# Altium schematic sheet Front-End-Power-Protection.SchDoc
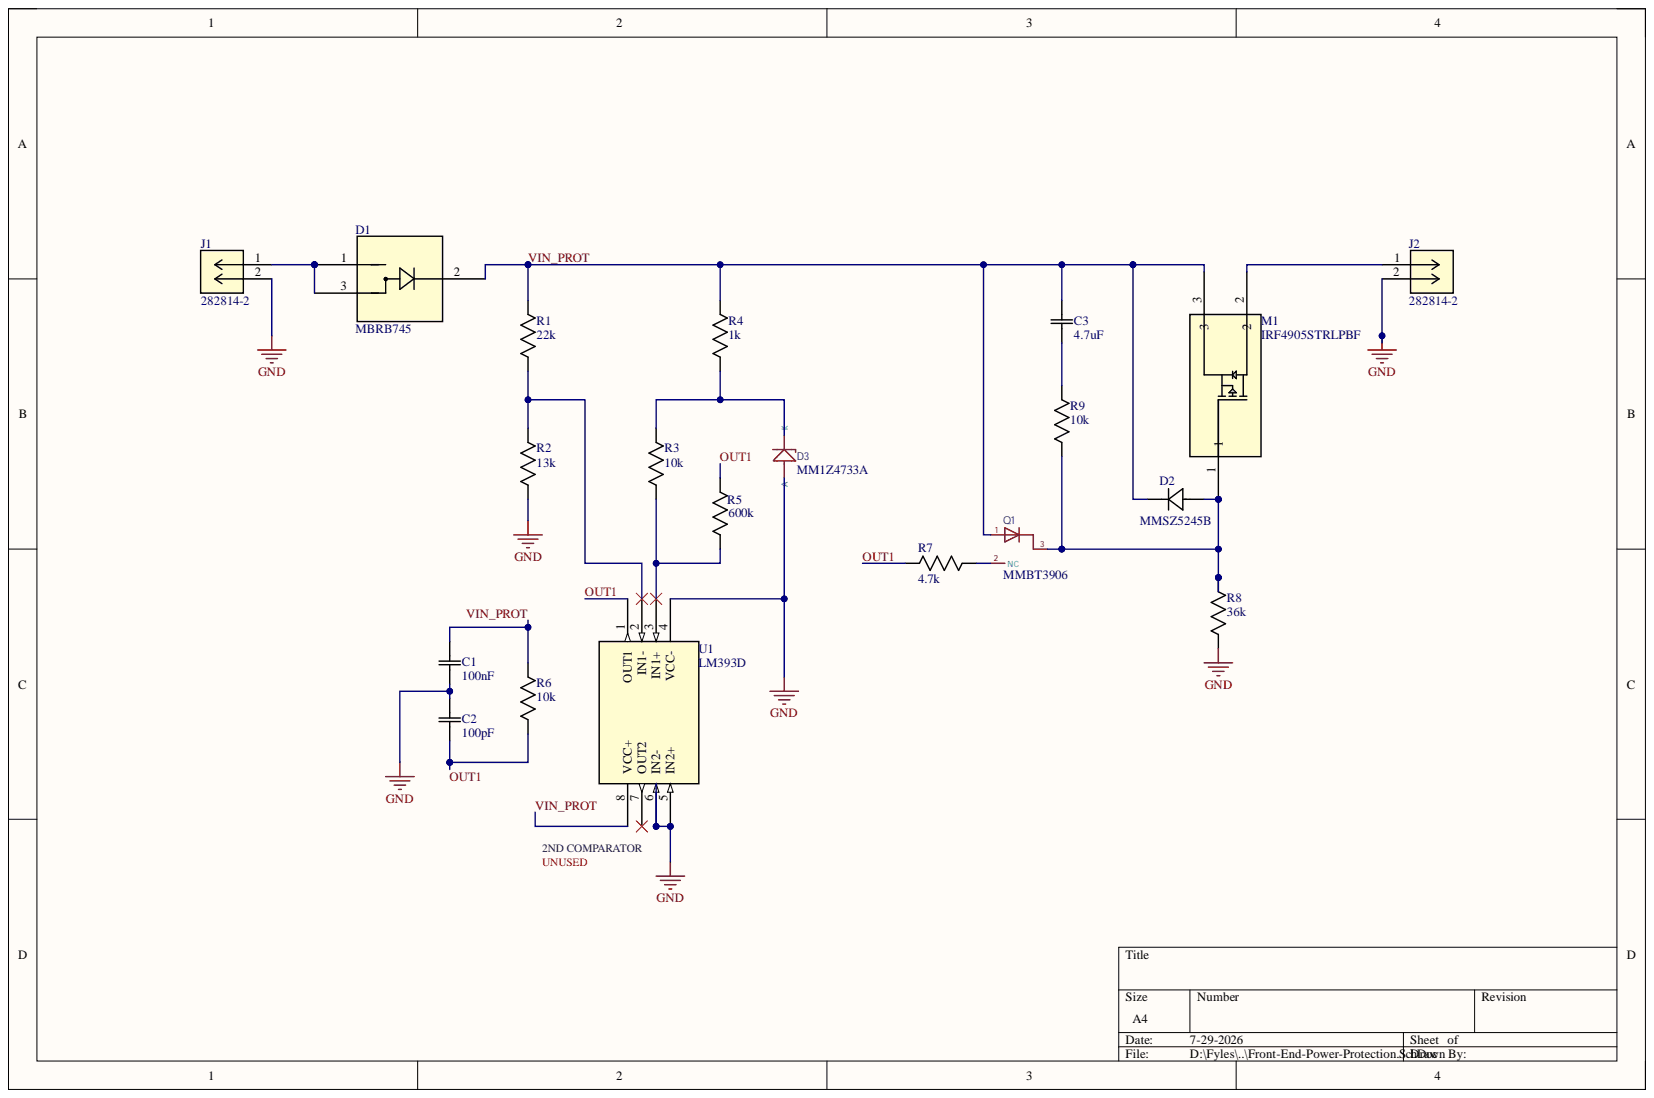
[diagram p0: Front-End-Power-Protection.SchDoc]

## Parts
C1  100nF  [CAP_X7R_0805_AVX]
C2  100pF  [CAP_X7R_0805_AVX]
C3  4.7uF  [CAP_CC1210_YAG]
D1  MBRB745  [D2PAK_VIS]
D2  MMSZ5245B  [SOD123_DIO]
D3  MM1Z4733A
J1  282814-2  [CONN2_282814-2]
J2  282814-2  [CONN2_282814-2]
M1  IRF4905STRLPBF  [TRAN_IRF4905STRLPBF_INF]
Q1  MMBT3906
R1  22k  [RC0805N_YAG]
R2  13k  [RC0805N_YAG]
R3  10k  [RC0805N_YAG]
R4  1k  [RC0805N_YAG]
R5  600k  [RC0805N_YAG]
R6  10k  [RC0805N_YAG]
R7  4.7k  [RC0805N_YAG]
R8  36k  [RC0805N_YAG]
R9  10k  [RC0805N_YAG]
U1  LM393D  [SO8_STM]

## Nets
GND: C1.1 C2.2 D3.A J1.2 J2.2 R2.2 R8.2 U1.4(VCC-) U1.5(IN2+) U1.6(IN2-)
OUT1: C2.1 R5.1 R6.2 R7.1 U1.1(OUT1)
VIN_PROT: C1.2 C3.2 D1.2 D2.1 M1.3 Q1.1 R1.1 R4.1 R6.1 U1.8(VCC+)
NetC3_1: C3.1 R9.1
NetD1_1: D1.1 D1.3 J1.1
NetD2_2: D2.2 M1.1 Q1.3 R8.1 R9.2
NetD3_K: D3.K R3.2 R4.2
NetJ2_1: J2.1 M1.2
NetQ1_2: Q1.2 R7.2
NetR1_2: R1.2 R2.1 U1.2(IN1-)
NetR3_1: R3.1 R5.2 U1.3(IN1+)

Not connected: U1 7(OUT2)

## Library data of the parts
C1 C2 = 08053C104KAT2A: Manufacturer_Name: KYOCERA AVX; Component Kind: Standard; Library Name: My_Custom_Components.IntLib; Manufacturer_Part_Number: 08053C104KAT2A; Copyright: Copyright (C) 2026 Ultra Librarian. All rights reserved.
  C1: Description: 08053C104KAT2A
C3 = CC1210KKX7R9BB475: Manufacturer_Name: Yageo; Component Kind: Standard; Description: CC1210KKX7R9BB475; Library Name: My_Custom_Components.IntLib; Manufacturer_Part_Number: CC1210KKX7R9BB475; Copyright: Copyright (C) 2026 Ultra Librarian. All rights reserved.
D1 = MBRB745: No Description Available; Manufacturer_Name: Vishay; Component Kind: Standard; Library Name: My_Custom_Components.IntLib; Manufacturer_Part_Number: MBRB745; Copyright: Copyright (C) 2026 Ultra Librarian. All rights reserved.
D2 = MMSZ5245B-7-F: Manufacturer_Name: Diodes Inc; Component Kind: Standard; Description: MMSZ5245B-7-F; Library Name: My_Custom_Components.IntLib; Manufacturer_Part_Number: MMSZ5245B-7-F; Copyright: Copyright (C) 2026 Ultra Librarian. All rights reserved.
D3 = MM1Z4733A: ki_description: CLD Current Limiting Diode; Reference: D; Value: CLD-Diode; Component Kind: Standard; Datasheet: https://diotec.com/de/produktliste/C.html; ki_keywords: CLD current limiting diode; Library Name: My_Custom_Components.IntLib
J1 J2 = 282814-2: TERMI-BLOK HEADER,ASSY90 2P.5; Manufacturer_Name: TE Connectivity; Component Kind: Standard; Datasheet: https://www.te.com/commerce/DocumentDelivery/DDEController?Action=srchrtrv&DocNm=1-1773458-1_EURO_STYLE_QRG&DocType=Data+Sheet&DocLang=English&PartCntxt=282814-2&DocFormat=pdf; Library Name: My_Custom_Components.IntLib; Manufacturer_Part_Number: 282814-2; RefDes: RefDes; Type: Type; Copyright: Copyright (C) 2026 Ultra Librarian. All rights reserved.
M1 = IRF4905STRLPBF: No Description Available; Manufacturer_Name: Infineon; Component Kind: Standard; Datasheet: https://www.infineon.com/dgdl/irf4905spbf.pdf?fileId=5546d462533600a4015355e331c41980; Library Name: My_Custom_Components.IntLib; Manufacturer_Part_Number: IRF4905STRLPBF; RefDes: RefDes; Type: Type; Copyright: Copyright (C) 2026 Ultra Librarian. All rights reserved.
Q1 = MMBT3906: ki_description: Diode with NC pin; ki_keywords: Doide NC pin; Reference: D; Value: Diode; Component Kind: Standard; Datasheet: https://diotec.com/de/produktliste/D.html; Library Name: My_Custom_Components.IntLib
R1-R9 = RC0805FR-0722KL: Manufacturer_Name: Yageo; Component Kind: Standard; Description: RC0805FR-0722KL; Digi-Key_Part_Number_1: 311-22.0KCRCT-ND; Digi-Key_Part_Number_2: 311-22.0KCRDKR-ND; Digi-Key_Part_Number_3: 311-22.0KCRTR-ND; Digi-Key_Part_Number_4: 311-22.0KCRTR-NDL; Library Name: My_Custom_Components.IntLib; Manufacturer_Part_Number: RC0805FR-0722KL; Copyright: Copyright (C) 2026 Ultra Librarian. All rights reserved.
U1 = LM393D: Low power dual voltage comparator; Manufacturer_Name: STMicroelectronics; Component Kind: Standard; Library Name: My_Custom_Components.IntLib; Manufacturer_Part_Number: LM393D; RefDes: RefDes; Type: Type; Copyright: Copyright (C) 2025 Ultra Librarian. All rights reserved.
